# Altium schematic sheet OpenPLCv0.2.0_TopLevel.SchDoc
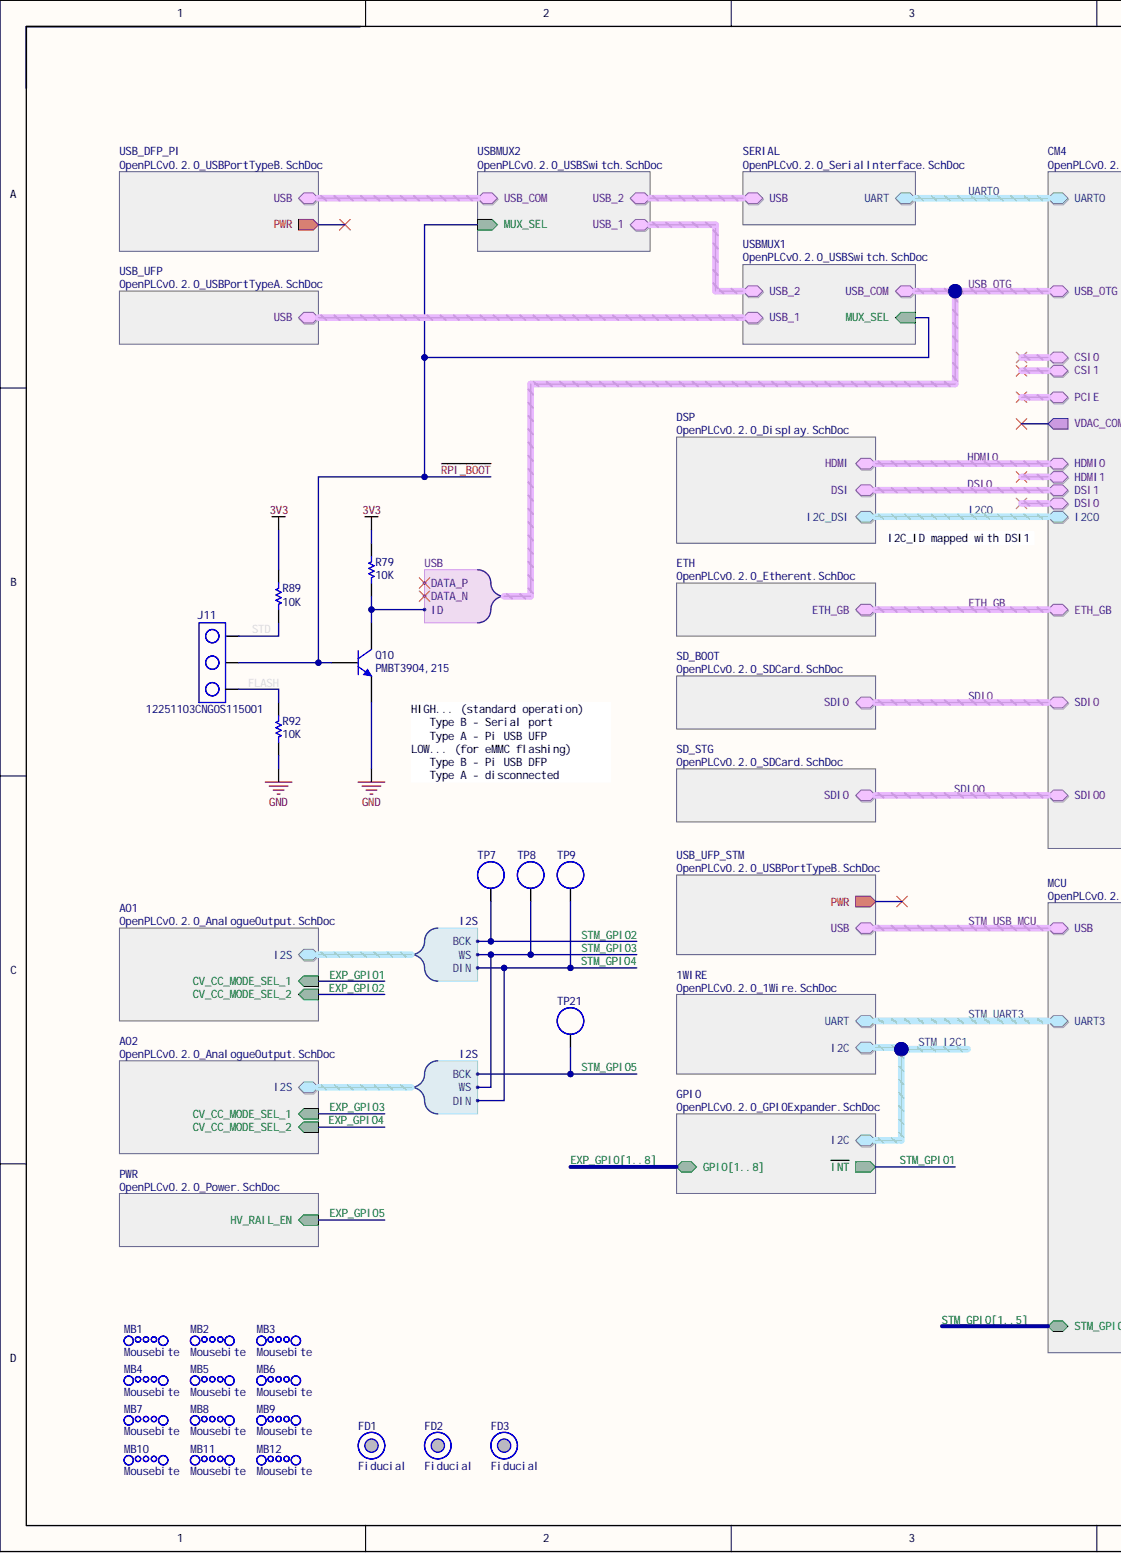
[diagram p0: OpenPLCv0.2.0_TopLevel.SchDoc, left]
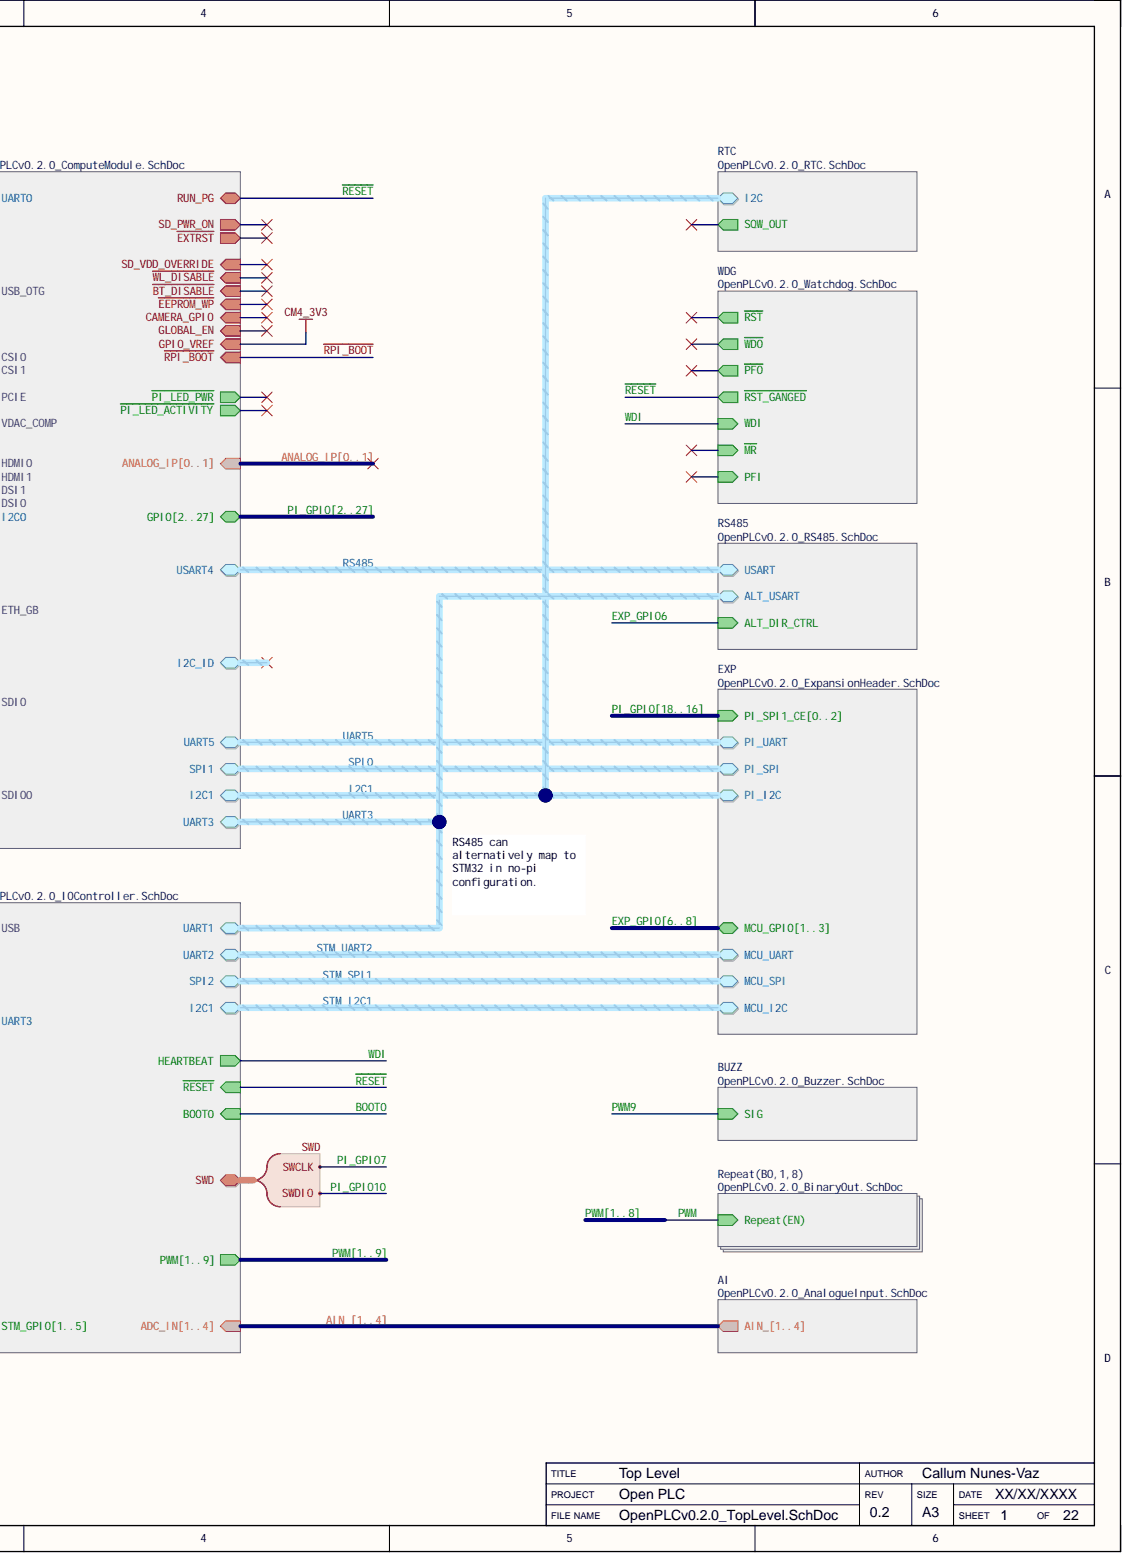
[diagram p1: OpenPLCv0.2.0_TopLevel.SchDoc, right]

## Parts
FD1  Fiducial  [Fiducial]
FD2  Fiducial  [Fiducial]
FD3  Fiducial  [Fiducial]
J11  12251103CNG0S115001  [Header_3POS]
MB1  Mousebite  [MOUSEBITE]  (no BOM)
MB2  Mousebite  [MOUSEBITE]  (no BOM)
MB3  Mousebite  [MOUSEBITE]  (no BOM)
MB4  Mousebite  [MOUSEBITE]  (no BOM)
MB5  Mousebite  [MOUSEBITE]  (no BOM)
MB6  Mousebite  [MOUSEBITE]  (no BOM)
MB7  Mousebite  [MOUSEBITE]  (no BOM)
MB8  Mousebite  [MOUSEBITE]  (no BOM)
MB9  Mousebite  [MOUSEBITE]  (no BOM)
MB10  Mousebite  [MOUSEBITE]  (no BOM)
MB11  Mousebite  [MOUSEBITE]  (no BOM)
MB12  Mousebite  [MOUSEBITE]  (no BOM)
Q10  PMBT3904,215  [SOT23-3]
R79  Resistance: 10K  [SMD_RES_0402(1005)_N]  (hidden comment: RC0402FR-0710KL)
R89  Resistance: 10K  [SMD_RES_0402(1005)_N]  (hidden comment: RC0402FR-0710KL)
R92  Resistance: 10K  [SMD_RES_0402(1005)_N]  (hidden comment: RC0402FR-0710KL)
TP7  TestPoint  [Pad]  (value not drawn)  (no BOM)
TP8  TestPoint  [Pad]  (value not drawn)  (no BOM)
TP9  TestPoint  [Pad]  (value not drawn)  (no BOM)
TP21  TestPoint  [Pad]  (value not drawn)  (no BOM)

## Nets
3V3: R79.1 R89.2
GND: Q10.2 R92.1
FLASH: J11.3 R92.2
~{RPI_BOOT}: J11.2 Q10.1
STD: J11.1 R89.1
STM_GPIO2: TP7.1  [also labelled STM_GPIO]
STM_GPIO3: TP8.1  [also labelled STM_GPIO]
STM_GPIO4: TP9.1  [also labelled STM_GPIO]
STM_GPIO5: TP21.1  [also labelled STM_GPIO]
USB_OTG.ID: Q10.3 R79.2
AIN_1:  [also labelled AIN_]
AIN_2:  [also labelled AIN_]
AIN_3:  [also labelled AIN_]
AIN_4:  [also labelled AIN_]
ANALOG_IP0:  [also labelled ANALOG_IP]
ANALOG_IP1:  [also labelled ANALOG_IP]
~{BT_DISABLE}:
BOOT0:
CAMERA_GPIO:
CM4_3V3:
CSI0.CLK_N:
CSI0.CLK_P:
CSI0.D0_N:
CSI0.D0_P:
CSI0.D1_N:
CSI0.D1_P:
CSI1.CLK_N:
CSI1.CLK_P:
CSI1.D0_N:
CSI1.D0_P:
CSI1.D1_N:
CSI1.D1_P:
CSI1.D2_N:
CSI1.D2_P:
CSI1.D3_N:
CSI1.D3_P:
DSI0.CLK_N:
DSI0.CLK_P:
DSI0.D0_N:
DSI0.D0_P:
DSI0.D1_N:
DSI0.D1_P:
DSI0.D2_N:
DSI0.D2_P:
DSI0.D3_N:
DSI0.D3_P:
~{EEPROM_WP}:
~{EXTRST}:
ETH_GB.D0_N:
ETH_GB.D0_P:
ETH_GB.D1_N:
ETH_GB.D1_P:
ETH_GB.D2_N:
ETH_GB.D2_P:
ETH_GB.D3_N:
ETH_GB.D3_P:
ETH_GB.LED1:
ETH_GB.LED2:
ETH_GB.LED3:
ETH_GB.SYNC_IN:
ETH_GB.SYNC_OUT:
EXP_GPIO1:  [also labelled EXP_GPIO]
EXP_GPIO2:  [also labelled EXP_GPIO]
EXP_GPIO3:  [also labelled EXP_GPIO]
EXP_GPIO4:  [also labelled EXP_GPIO]
EXP_GPIO5:  [also labelled EXP_GPIO]
EXP_GPIO6:  [also labelled EXP_GPIO]
EXP_GPIO7:  [also labelled EXP_GPIO]
EXP_GPIO8:  [also labelled EXP_GPIO]
EXP_GPIO[6..8]:  [also labelled EXP_GPIO]
GLOBAL_EN:
HDMI0.CEC:
HDMI0.CLK_N:
HDMI0.CLK_P:
HDMI0.D0_N:
HDMI0.D0_P:
HDMI0.D1_N:
HDMI0.D1_P:
HDMI0.D2_N:
HDMI0.D2_P:
HDMI0.HPD:
HDMI0.SCL:
HDMI0.SDA:
HDMI1.CEC:
HDMI1.CLK_N:
HDMI1.CLK_P:
HDMI1.D0_N:
HDMI1.D0_P:
HDMI1.D1_N:
HDMI1.D1_P:
HDMI1.D2_N:
HDMI1.D2_P:
HDMI1.HPD:
HDMI1.SCL:
HDMI1.SDA:
I2C0.SCL:
I2C0.SDA:
I2C1.SCL:
I2C1.SDA:
I2C_ID.SCL:
I2C_ID.SDA:
~{MR}:
~{PFO}:
~{PI_LED_ACTIVITY}:
~{PI_LED_PWR}:
PCIE.CLK_N:
PCIE.CLK_P:
PCIE.CLK_REQ:
PCIE.RST:
PCIE.RX_N:
PCIE.RX_P:
PCIE.TX_N:
PCIE.TX_P:
PFI:
PI_GPIO2:  [also labelled PI_GPIO]
PI_GPIO3:  [also labelled PI_GPIO]
PI_GPIO4:  [also labelled PI_GPIO]
PI_GPIO5:  [also labelled PI_GPIO]
PI_GPIO6:  [also labelled PI_GPIO]
PI_GPIO7:  [also labelled PI_GPIO]
PI_GPIO8:  [also labelled PI_GPIO]
PI_GPIO9:  [also labelled PI_GPIO]
PI_GPIO10:  [also labelled PI_GPIO]
PI_GPIO11:  [also labelled PI_GPIO]
PI_GPIO12:  [also labelled PI_GPIO]
PI_GPIO13:  [also labelled PI_GPIO]
PI_GPIO14:  [also labelled PI_GPIO]
PI_GPIO15:  [also labelled PI_GPIO]
PI_GPIO16:  [also labelled PI_GPIO]
PI_GPIO17:  [also labelled PI_GPIO]
PI_GPIO18:  [also labelled PI_GPIO]
PI_GPIO19:  [also labelled PI_GPIO]
PI_GPIO20:  [also labelled PI_GPIO]
PI_GPIO21:  [also labelled PI_GPIO]
PI_GPIO22:  [also labelled PI_GPIO]
PI_GPIO23:  [also labelled PI_GPIO]
PI_GPIO24:  [also labelled PI_GPIO]
PI_GPIO25:  [also labelled PI_GPIO]
PI_GPIO26:  [also labelled PI_GPIO]
PI_GPIO27:  [also labelled PI_GPIO]
PI_GPIO[18..16]:  [also labelled PI_GPIO]
PWM1:  [also labelled PWM]
PWM2:  [also labelled PWM]
PWM3:  [also labelled PWM]
PWM4:  [also labelled PWM]
PWM5:  [also labelled PWM]
PWM6:  [also labelled PWM]
PWM7:  [also labelled PWM]
PWM8:  [also labelled PWM]
PWM9:  [also labelled PWM]
PWM[1..8]:  [also labelled PWM]
PWR:
~{RESET}:
~{RST}:
RS485.CTS:
RS485.RTS:
RS485.RX:
RS485.TX:
SD_PWR_ON:
SD_VDD_OVERRIDE:
SDIO0.CLK:  [also labelled SDIO.CLK, SDIO.CMD, SDIO.DATA0, SDIO.DATA1, SDIO.DATA2, SDIO.DATA3, SDIO.DATA4, SDIO.DATA5, SDIO.DATA6, SDIO.DATA7]
SDIO0.CMD:
SDIO0.DATA0:
SDIO0.DATA1:
SDIO0.DATA2:
SDIO0.DATA3:
SDIO0.DATA4:
SDIO0.DATA5:
SDIO0.DATA6:
SDIO0.DATA7:
SPI0.MISO:
SPI0.MOSI:
SPI0.SCLK:
SQW_OUT:
STM_GPIO1:  [also labelled STM_GPIO]
STM_I2C1.SCL:
STM_I2C1.SDA:
STM_SPI1.MISO:
STM_SPI1.MOSI:
STM_SPI1.SCLK:
STM_UART2.RX:
STM_UART2.TX:
STM_UART3.RX:
STM_UART3.TX:
STM_USB_MCU.DATA_N:
STM_USB_MCU.DATA_P:
STM_USB_MCU.ID:
UART0.RX:
UART0.TX:
UART3.RX:
UART3.TX:
UART5.RX:
UART5.TX:
USB.DATA_N:
USB.DATA_P:
USB.ID:
USB_1.DATA_N:
USB_1.DATA_P:
USB_1.ID:
USB_OTG.DATA_N:
USB_OTG.DATA_P:
VDAC_COMP:
~{WDO}:
~{WL_DISABLE}:
WDI:

## Library data of the parts
J11 = 3-way Jumper: Pin Header 3 1 right-angle，180degrees 2.54mm Through Hole,P=2.54mm Pin Header & Female Header RoHS; Component Kind: Standard; Library Name: BrauV2_Connector.SchLib
Q10 = PMBT3904,215: NPN 200mA 40V 250mW SOT-23(SOT-23-3) Transistors (NPN/PNP) RoHS; Component Kind: Standard; Library Name: BrauV2_Transistor.SchLib
R79 R89 R92 = 10K 0402 1% 1/16W: 10kΩ ±1% 1/16W ±100ppm/℃ 0402 Chip Resistor - Surface Mount RoHS; Component Kind: Standard; Package: 0402; Power Rating: 1/16W; Tolerance: 1%; Library Name: BrauV2_Resistor.SchLib
TP7-TP9 TP21 = Test point: Library Name: OpenPLCv0.2.0_Misc.SchLib
FD1-FD3 = Fiducial: Component Kind: Standard; Library Name: OpenPLCv0.2.0_Misc.SchLib
MB1-MB12 = Mousebite: Library Name: OpenPLCv0.2.0_Misc.SchLib
